# Altium schematic sheet WM_main.SchDoc
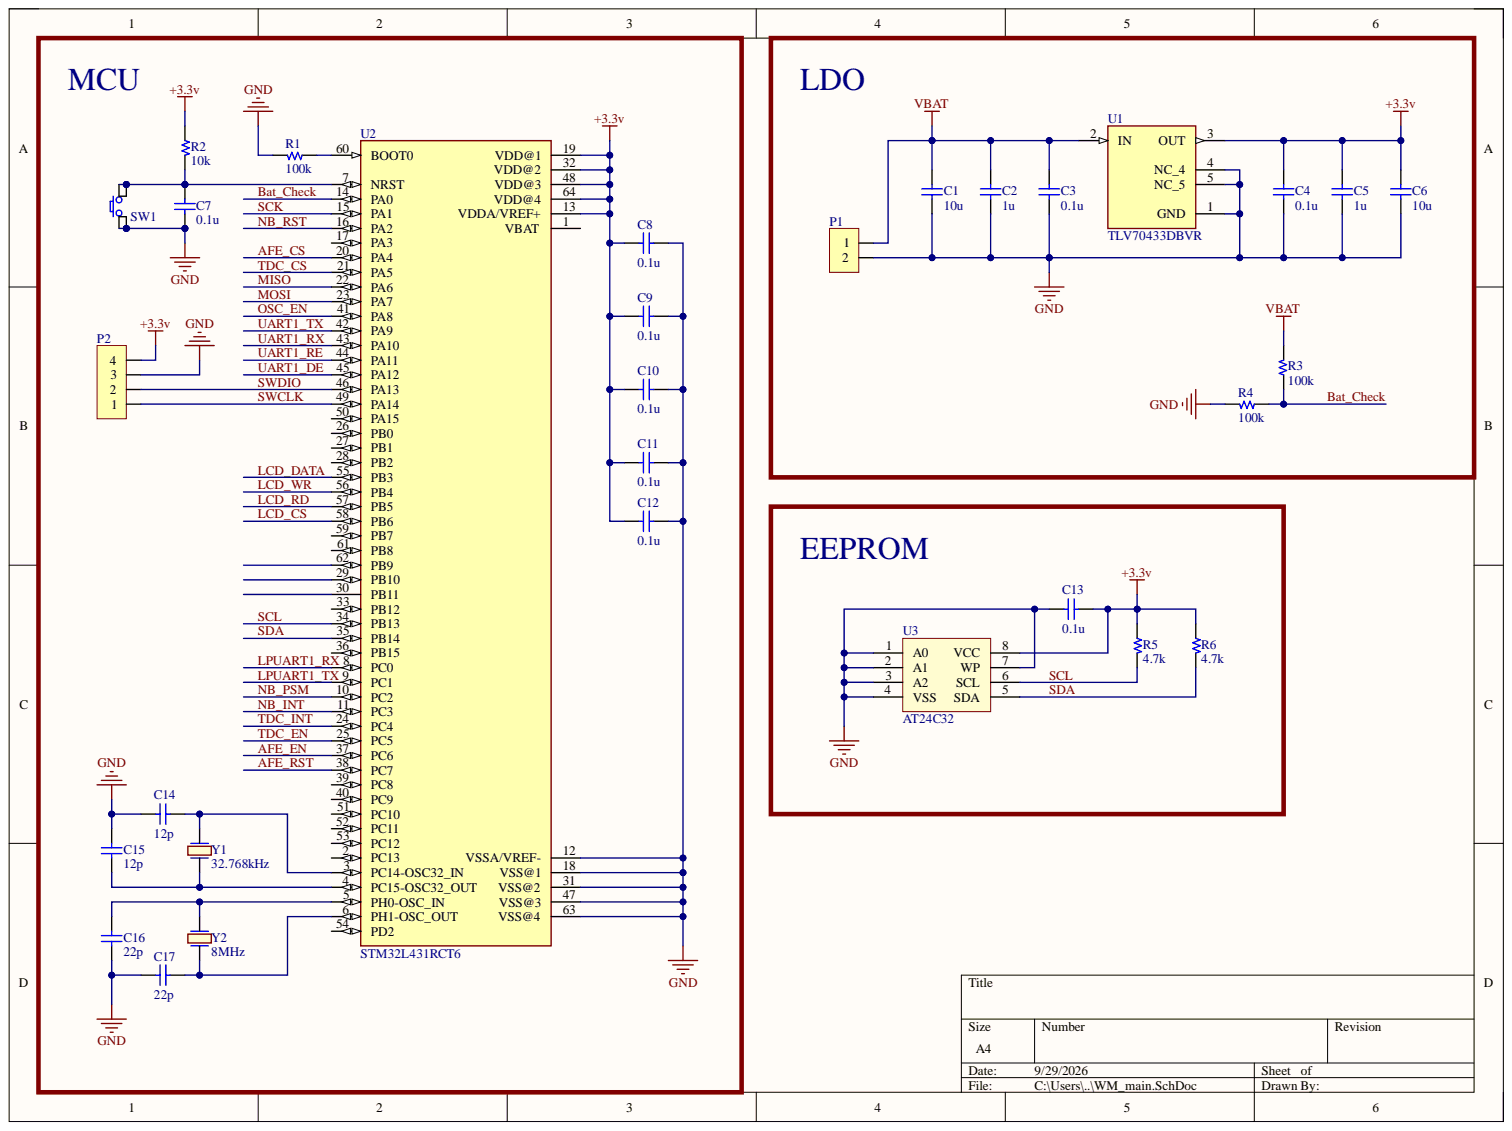
[diagram p0: WM_main.SchDoc]

## Parts
C1  10u  [C 0603]
C2  1u  [C 0603]
C3  0.1u  [C 0603]
C4  0.1u  [C 0603]
C5  1u  [C 0603]
C6  10u  [C 0603]
C7  0.1u  [C 0603]
C8  0.1u  [C 0603]
C9  0.1u  [C 0603]
C10  0.1u  [C 0603]
C11  0.1u  [C 0603]
C12  0.1u  [C 0603]
C13  0.1u  [C 0603]
C14  12p  [C 0603]
C15  12p  [C 0603]
C16  22p  [C 0603]
C17  22p  [C 0603]
P1  JUMP 2P 2.54mm  [HDR/Header/2]  (value not drawn)
P2  JUMP 4P 2.54mm  [HDR/Header/4]  (value not drawn)
R1  100k  [R 0603]
R2  10k  [R 0603]
R3  100k  [R 0603]
R4  100k  [R 0603]
R5  4.7k  [R 0603]
R6  4.7k  [R 0603]
SW1  Button  [SW/4/06MM]  (value not drawn)
U1  TLV70433DBVR  [SOT95P280X145-5N]
U2  STM32L431RCT6  [QFP50P1200X1200X160-64N]
U3  AT24C32  [SOIC-8]
Y1  32.768kHz  [Crystal 3215]
Y2  8MHz  [Crystal 3215]

## Nets
+3.3v: C4.1 C5.1 C6.1 C8.1 C9.1 C10.1 C11.1 C12.1 C13.2 P2.4 R2.1 R5.1 R6.1 U1.3(OUT) U2.13(VDDA/VREF+) U2.19(VDD@1) U2.32(VDD@2) U2.48(VDD@3) U2.64(VDD@4) U3.8(VCC)
GND: C1.1 C2.1 C3.1 C4.2 C5.2 C6.2 C7.1 C8.2 C9.2 C10.2 C11.2 C12.2 C13.1 C14.1 C15.2 C16.1 C17.1 P1.2 P2.3 R1.2 R4.2 SW1.1 SW1.2 U1.1(GND) U1.4(NC_4) U1.5(NC_5) U2.12(VSSA/VREF-) U2.18(VSS@1) U2.31(VSS@2) U2.47(VSS@3) U2.63(VSS@4) U3.1(A0) U3.2(A1) U3.3(A2) U3.4(VSS) U3.7(WP)
VBAT: C1.2 C2.2 C3.2 P1.1 R3.2 U1.2(IN)
AFE_CS: U2.20(PA4)
AFE_EN: U2.37(PC6)
AFE_RST: U2.38(PC7)
Bat_Check: R3.1 R4.1 U2.14(PA0)
LCD_CS: U2.58(PB6)
LCD_DATA: U2.55(PB3)
LCD_RD: U2.57(PB5)
LCD_WR: U2.56(PB4)
LPUART1_RX: U2.8(PC0)
LPUART1_TX: U2.9(PC1)
MISO: U2.22(PA6)
MOSI: U2.23(PA7)
NB_INT: U2.11(PC3)
NB_PSM: U2.10(PC2)
NB_RST: U2.16(PA2)
OSC_EN: U2.41(PA8)
SCK: U2.15(PA1)
SCL: R5.2 U2.34(PB13) U3.6(SCL)
SDA: R6.2 U2.35(PB14) U3.5(SDA)
SWCLK: P2.1 U2.49(PA14)
SWDIO: P2.2 U2.46(PA13)
TDC_CS: U2.21(PA5)
TDC_EN: U2.25(PC5)
TDC_INT: U2.24(PC4)
UART1_DE: U2.45(PA12)
UART1_RE: U2.44(PA11)
UART1_RX: U2.43(PA10)
UART1_TX: U2.42(PA9)
NetC7_2: C7.2 R2.2 SW1.3 SW1.4 U2.7(NRST)
NetC14_2: C14.2 U2.3(PC14-OSC32_IN) Y1.1
NetC15_1: C15.1 U2.4(PC15-OSC32_OUT) Y1.2
NetC16_2: C16.2 U2.5(PH0-OSC_IN) Y2.1
NetC17_2: C17.2 U2.6(PH1-OSC_OUT) Y2.2
NetR1_1: R1.1 U2.60(BOOT0)

Not connected: U2 1(VBAT) 2(PC13) 17(PA3) 26(PB0) 27(PB1) 28(PB2) 29(PB10) 30(PB11) 33(PB12) 36(PB15) 39(PC8) 40(PC9) 50(PA15) 51(PC10) 52(PC11) 53(PC12) 54(PD2) 59(PB7) 61(PB8) 62(PB9)

## Library data of the parts
C1-C6 = Capacitor NP: Ceramic capacitor X7R/X5R; Component Kind: Standard; Library Name: chipfc_altium_libs.IntLib
C7-C17 = Capacitor NP: Ceramic capacitor; Component Kind: Standard; Library Name: chipfc_altium_libs.IntLib
P1 = Header 2: Pin 3.6V; Component Kind: Standard; Library Name: chipfc_altium_libs.IntLib
P2 = Header 4: SWD; Component Kind: Standard; Library Name: chipfc_altium_libs.IntLib
R1-R6 = Resistor: Component Kind: Standard; Description: Resistor; Library Name: chipfc_altium_libs.IntLib
SW1 = SW SPST 4-pin: Tact switch 4-pin; Component Kind: Standard; Library Name: chipfc_altium_libs.IntLib
U1 = TLV70433DBVR: 150-mA 24-V ultra-low-IQ low-dropout (LDO) voltage regulator; MF: Texas Instruments; Check_prices: https://www.snapeda.com/parts/TLV70433DBVR/Texas+Instruments/view-part/?ref=eda; Component Kind: Standard; Library Name: TLV70433DBVR.IntLib; MP: TLV70433DBVR; Package: SOT-23-5 Texas Instruments; SnapEDA_Link: https://www.snapeda.com/parts/TLV70433DBVR/Texas+Instruments/view-part/?ref=snap
U2 = STM32L431RCT6: ARM® Cortex-M4 STM32L4 Microcontroller IC 32-Bit Single-Core 80MHz 256KB (256K x 8) FLASH 64-LQFP (10x10); MF: STMicroelectronics; Check_prices: https://www.snapeda.com/parts/STM32L431RCT6/STMicroelectronics/view-part/?ref=eda; Component Kind: Standard; Library Name: STM32L431RCT6.IntLib; MP: STM32L431RCT6; Package: LQFP-64 STMicroelectronics; SnapEDA_Link: https://www.snapeda.com/parts/STM32L431RCT6/STMicroelectronics/view-part/?ref=snap
U3 = 24LCxxx: 2-Wire Serial EEPROM; Component Kind: Standard; Library Name: chipfc_altium_libs.IntLib
Y1 Y2 = Crystal: Crystal 2p 3215; Component Kind: Standard; Library Name: chipfc_altium_libs.IntLib
